# Altium schematic sheet IMX6ULL_MINI_CORE.SchDoc(IMX6ULL_MINI_CORE)
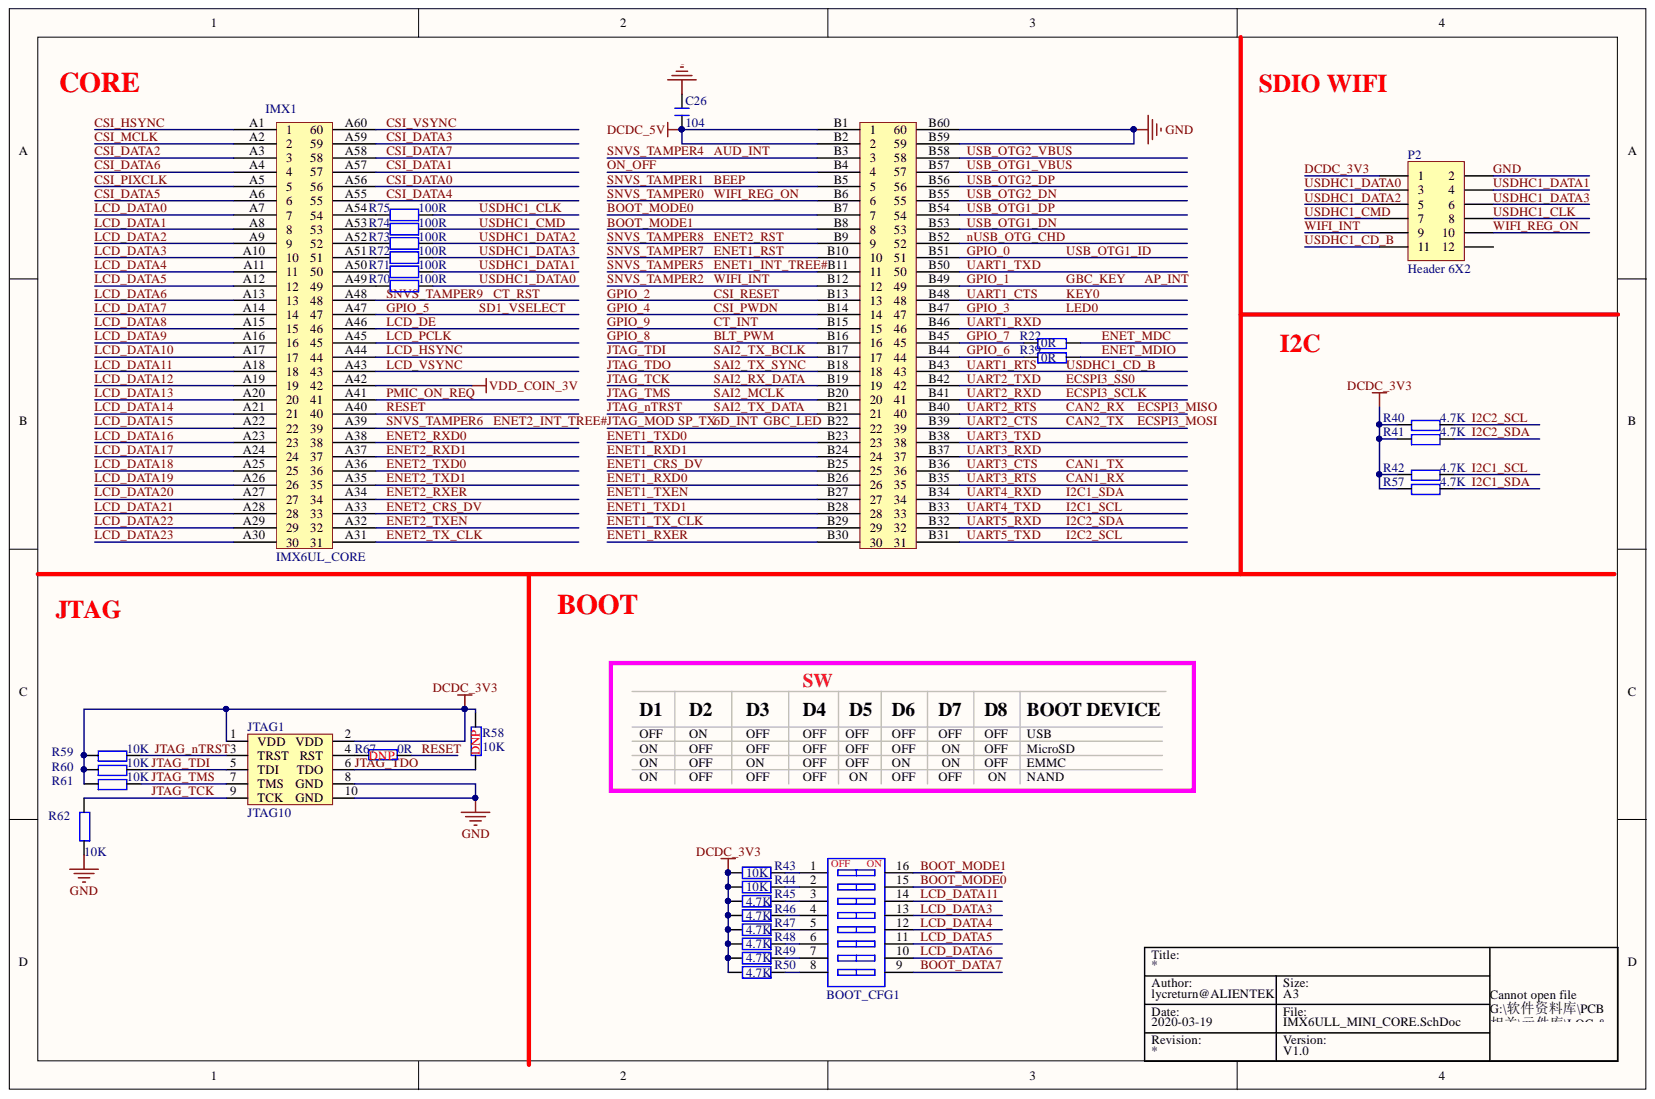
[diagram p0: IMX6ULL_MINI_CORE.SchDoc]

## Parts
BOOT_CFG1  CSW-8P  [CSW DIP-8P]  (value not drawn)
C26  104  [C 0603]
IMX1  IMX6UL_CORE  [I.MX6UL_CORE]
JTAG1  JTAG10  [JTAG-10 2.0]
P2  Header 6X2  [HDR2X6]
R22  0R  [R 0603]
R39  0R  [R 0603]
R40  4.7K  [R 0603]
R41  4.7K  [R 0603]
R42  4.7K  [R 0603]
R43  10K  [R 0603]
R44  10K  [R 0603]
R45  4.7K  [R 0603]
R46  4.7K  [R 0603]
R47  4.7K  [R 0603]
R48  4.7K  [R 0603]
R49  4.7K  [R 0603]
R50  4.7K  [R 0603]
R57  4.7K  [R 0603]
R58  10K  [R 0603]
R59  10K  [R 0603]
R60  10K  [R 0603]
R61  10K  [R 0603]
R62  10K  [R 0603]
R67  0R  [R 0603]
R70  100R  [R 0603]
R71  100R  [R 0603]
R72  100R  [R 0603]
R73  100R  [R 0603]
R74  100R  [R 0603]
R75  100R  [R 0603]

## Nets
DCDC_5V: C26.1 IMX1.B1 IMX1.B2
VDD_COIN_3V: IMX1.A42
6D_INT: IMX1.B22
AP_INT: IMX1.B49
AUD_INT: IMX1.B3
BEEP: IMX1.B5
BLT_PWM: IMX1.B16
BOOT_DATA7: BOOT_CFG1.9
BOOT_MODE0: BOOT_CFG1.15 IMX1.B7
BOOT_MODE1: BOOT_CFG1.16 IMX1.B8
CAN1_RX: IMX1.B35
CAN1_TX: IMX1.B36
CAN2_RX: IMX1.B40
CAN2_TX: IMX1.B39
CSI_DATA0: IMX1.A56
CSI_DATA1: IMX1.A57(CSI_DATA1)
CSI_DATA2: IMX1.A3
CSI_DATA3: IMX1.A59
CSI_DATA4: IMX1.A55
CSI_DATA5: IMX1.A6
CSI_DATA6: IMX1.A4
CSI_DATA7: IMX1.A58
CSI_HSYNC: IMX1.A1
CSI_MCLK: IMX1.A2
CSI_PIXCLK: IMX1.A5
CSI_PWDN: IMX1.B14
CSI_RESET: IMX1.B13
CSI_VSYNC: IMX1.A60
CT_INT: IMX1.B15
CT_RST: IMX1.A48(SNVS_TAMPER9)
DCDC_3V3: JTAG1.1(VDD) JTAG1.2(VDD) P2.1 R40.1 R41.1 R42.1 R43.1 R44.1 R45.1 R46.1 R47.1 R48.1 R49.1 R50.1 R57.1 R58.2 R59.1 R60.1 R61.1
ECSPI3_SCLK: IMX1.B41
ECSPI3_SS0: IMX1.B42
ENET1_CRS_DV: IMX1.B25
ENET1_INT_TREE#: IMX1.B11
ENET1_RST: IMX1.B10
ENET1_RXD0: IMX1.B26
ENET1_RXD1: IMX1.B24
ENET1_RXER: IMX1.B30
ENET1_TX_CLK: IMX1.B29
ENET1_TXD0: IMX1.B23
ENET1_TXD1: IMX1.B28
ENET1_TXEN: IMX1.B27
ENET2_CRS_DV: IMX1.A33
ENET2_INT_TREE#: IMX1.A39
ENET2_RST: IMX1.B9
ENET2_RXD0: IMX1.A38
ENET2_RXD1: IMX1.A37
ENET2_RXER: IMX1.A34
ENET2_TX_CLK: IMX1.A31
ENET2_TXD0: IMX1.A36
ENET2_TXD1: IMX1.A35
ENET2_TXEN: IMX1.A32
ENET_MDC: R22.2
ENET_MDIO: R39.2
GND: C26.2 IMX1.B59 IMX1.B60 JTAG1.8(GND) JTAG1.10(GND) P2.2 R62.1
GPIO_0: IMX1.B51
GPIO_3: IMX1.B47
GPIO_5: IMX1.A47(GPIO_5)
GPIO_6: IMX1.B44 R39.1
GPIO_7: IMX1.B45 R22.1
I2C1_SCL: IMX1.B33 R42.2
I2C1_SDA: IMX1.B34 R57.2
I2C2_SCL: IMX1.B31 R40.2
I2C2_SDA: IMX1.B32 R41.2
JTAG_NTRST: IMX1.B21(SAI2_TX_DATA) JTAG1.3(TRST) R59.2
JTAG_TCK: IMX1.B19(SAI2_RX_DATA) JTAG1.9(TCK) R62.2
JTAG_TDI: IMX1.B17 JTAG1.5(TDI) R60.2
JTAG_TDO: IMX1.B18(SAI2_TX_SYNC) JTAG1.6(TDO) R58.1
JTAG_TMS: IMX1.B20 JTAG1.7(TMS) R61.2
KEY0: IMX1.B48
LCD_DATA0: IMX1.A7
LCD_DATA1: IMX1.A8
LCD_DATA2: IMX1.A9
LCD_DATA3: BOOT_CFG1.13 IMX1.A10
LCD_DATA4: BOOT_CFG1.12 IMX1.A11
LCD_DATA5: BOOT_CFG1.11 IMX1.A12
LCD_DATA6: BOOT_CFG1.10 IMX1.A13
LCD_DATA7: IMX1.A14
LCD_DATA8: IMX1.A15
LCD_DATA9: IMX1.A16
LCD_DATA10: IMX1.A17
LCD_DATA11: BOOT_CFG1.14 IMX1.A18
LCD_DATA12: IMX1.A19
LCD_DATA13: IMX1.A20
LCD_DATA14: IMX1.A21
LCD_DATA15: IMX1.A22
LCD_DATA16: IMX1.A23
LCD_DATA17: IMX1.A24
LCD_DATA18: IMX1.A25
LCD_DATA19: IMX1.A26
LCD_DATA20: IMX1.A27
LCD_DATA21: IMX1.A28
LCD_DATA22: IMX1.A29
LCD_DATA23: IMX1.A30
LCD_DE: IMX1.A46
LCD_HSYNC: IMX1.A44
LCD_PCLK: IMX1.A45(LCD_PCLK)
LCD_VSYNC: IMX1.A43(LCD_VSYNC)
NUSB_OTG_CHD: IMX1.B52
ON_OFF: IMX1.B4
PMIC_ON_REQ: IMX1.A41
RESET: IMX1.A40(RESET) R67.1
SNVS_TAMPER0: IMX1.B6 P2.10
SNVS_TAMPER2: IMX1.B12 P2.9
UART1_RTS: IMX1.B43 P2.11
UART1_RXD: IMX1.B46
UART1_TXD: IMX1.B50
UART3_RXD: IMX1.B37
UART3_TXD: IMX1.B38
USB_OTG1_DN: IMX1.B53
USB_OTG1_DP: IMX1.B54
USB_OTG1_VBUS: IMX1.B57
USB_OTG2_DN: IMX1.B55
USB_OTG2_DP: IMX1.B56
USB_OTG2_VBUS: IMX1.B58
USDHC1_CLK: P2.8 R75.2
USDHC1_CMD: P2.7 R74.2
USDHC1_DATA0: P2.3 R70.2
USDHC1_DATA1: P2.4 R71.2
USDHC1_DATA2: P2.5 R73.2
USDHC1_DATA3: P2.6 R72.2
NetBOOT_CFG1_1: BOOT_CFG1.1 R43.2
NetBOOT_CFG1_2: BOOT_CFG1.2 R44.2
NetBOOT_CFG1_3: BOOT_CFG1.3 R45.2
NetBOOT_CFG1_4: BOOT_CFG1.4 R46.2
NetBOOT_CFG1_5: BOOT_CFG1.5 R47.2
NetBOOT_CFG1_6: BOOT_CFG1.6 R48.2
NetBOOT_CFG1_7: BOOT_CFG1.7 R49.2
NetBOOT_CFG1_8: BOOT_CFG1.8 R50.2
NetIMX1_A49: IMX1.A49 R70.1
NetIMX1_A50: IMX1.A50 R71.1
NetIMX1_A51: IMX1.A51 R72.1
NetIMX1_A52: IMX1.A52 R73.1
NetIMX1_A53: IMX1.A53 R74.1
NetIMX1_A54: IMX1.A54 R75.1
NetJTAG1_4: JTAG1.4(RST) R67.2

Not connected: P2 12

## Library data of the parts
BOOT_CFG1 = CSW-8P: 8路编码开关; 版权归属: 源创客; BOM名称: 8路编码开关; Component Kind: Standard; Library Name: 按键-开关.SchLib; 学习资源: www.ooocke.com
C26 = C: 无极性贴片电容; 版权归属: 源创客; BOM名称: 无极性贴片电容; Component Kind: Standard; Library Name: 电阻-电容-电感.SchLib; 学习资源: www.ooocke.com
IMX1 = I.MX6UL核心板: Component Kind: Standard; Description: I.MX6UL核心板; Library Name: IMX6UL_ALPHA_V1.0.IntLib
JTAG1 = JTAG-10 2.0: Component Kind: Standard; Library Name: ZZK_COMPONENT.IntLib
P2 = Header 6X2: Header, 6-Pin, Dual row; Component Kind: Standard; LatestRevisionDate: 17-Jul-2002; LatestRevisionNote: Re-released for DXP Platform.; Library Name: Miscellaneous Connectors.IntLib; Signal Integrity: Connector; Publisher: Altium Limited
R22 R39-R42 R57-R62 R67 = R: Component Kind: Standard; Library Name: 电阻-电容-电感.SchLib
R43-R50 R70-R75 = R: 贴片电阻; 版权归属: 源创客; BOM名称: 贴片电阻; Component Kind: Standard; 学习资源: www.ooocke.com
  R43-R50: Library Name: 电阻-电容-电感.SchLib
  R70-R75: Library Name: Lycreturn.IntLib
